# Altium schematic sheet Port.SchDoc
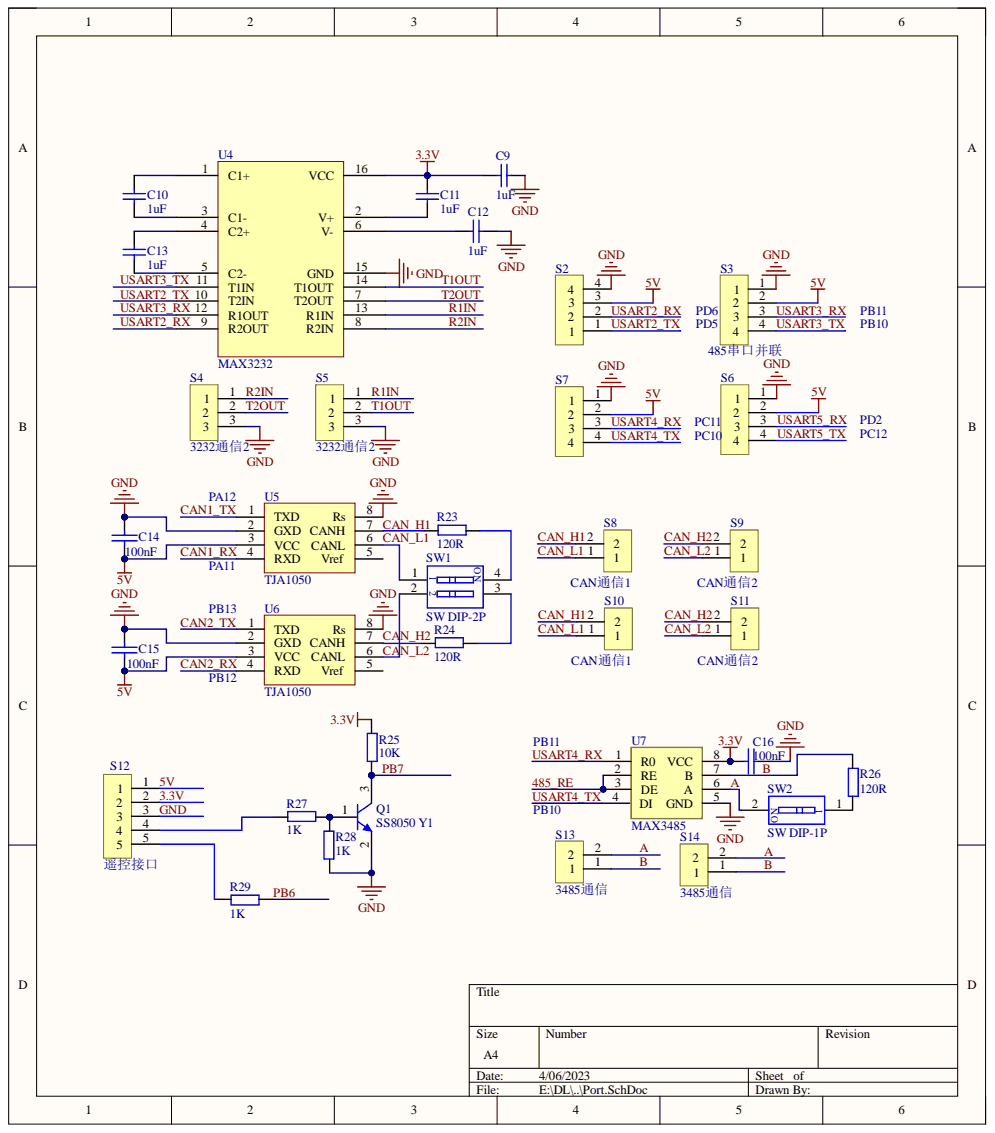
[diagram p0: Port.SchDoc]

## Parts
C9  1uF  [0402_C]
C10  1uF  [0402_C]
C11  1uF  [0402_C]
C12  1uF  [0402_C]
C13  1uF  [0402_C]
C14  100nF  [0402_C]
C15  100nF  [0402_C]
C16  100nF  [0402_C]
Q1  SS8050 Y1  [SOT-23]
R23  120R  [0402_R]
R24  120R  [0402_R]
R25  10K  [0402_R]
R26  120R  [0402_R]
R27  1K  [0402_R]
R28  1K  [0402_R]
R29  1K  [0402_R]
S2  (no value)  [卧式PH2.0mm-4P]
S3  485串口并联  [HDR2.54-LI-4P]
S4  3232通信2  [卧式PH2.0mm-3P]
S5  3232通信2  [卧式PH2.0mm-3P]
S6  (no value)  [HDR2.54-LI-4P]
S7  (no value)  [HDR2.54-LI-4P]
S8  CAN通信1  [卧式PH2.0mm-2P]
S9  CAN通信2  [卧式PH2.0mm-2P]
S10  CAN通信1  [卧式PH2.0mm-2P]
S11  CAN通信2  [卧式PH2.0mm-2P]
S12  遥控接口  [GH1.25-WS-5P]
S13  3485通信  [卧式PH2.0mm-2P]
S14  3485通信  [卧式PH2.0mm-2P]
SW1  SW DIP-2P  [SW DIP-1.27-2P]
SW2  SW DIP-1P  [SW DIP-1.27-1P]
U4  MAX3232  [SOP16_M]
U5  TJA1050  [SOP8_M]
U6  TJA1050  [SOP8_M]
U7  MAX3485  [SOP8_M]

## Nets
3.3V: C9.1 C11.2 C16.1 R25.2 S12.2 U4.16(VCC) U7.8(VCC)
5V: C14.2 C15.2 S2.3 S3.2 S6.2 S7.2 S12.1 U5.3(VCC) U6.3(VCC)
485_RE: U7.2(RE) U7.3(DE)
A: S13.2 S14.2 SW2.2 U7.6(A)
B: R26.2 S13.1 S14.1 U7.7(B)
CAN1_RX: U5.4(RXD)
CAN1_TX: U5.1(TXD)
CAN2_RX: U6.4(RXD)
CAN2_TX: U6.1(TXD)
CAN_H1: R23.2 S8.2 S10.2 U5.7(CANH)
CAN_H2: R24.2 S9.2 S11.2 U6.7(CANH)
CAN_L1: S8.1 S10.1 SW1.1 U5.6(CANL)
CAN_L2: S9.1 S11.1 SW1.2 U6.6(CANL)
GND: C9.2 C12.2 C14.1 C15.1 C16.2 Q1.2 R28.2 S2.4 S3.1 S4.3 S5.3 S6.1 S7.1 S12.3 U4.15(GND) U5.2(GXD) U5.8(Rs) U6.2(GXD) U6.8(Rs) U7.5(GND)
PB6: R29.2
PB7: Q1.3 R25.1
R1IN: S5.1 U4.13(R1IN)
R2IN: S4.1 U4.8(R2IN)
T1OUT: S5.2 U4.14(T1OUT)
T2OUT: S4.2 U4.7(T2OUT)
USART2_RX: S2.2 U4.9(R2OUT)
USART2_TX: S2.1 U4.10(T2IN)
USART3_RX: S3.3 U4.12(R1OUT)
USART3_TX: S3.4 U4.11(T1IN)
USART4_RX: S7.3 U7.1(R0)
USART4_TX: S7.4 U7.4(DI)
USART5_RX: S6.3
USART5_TX: S6.4
NetC10_1: C10.1 U4.3(C1-)
NetC10_2: C10.2 U4.1(C1+)
NetC11_1: C11.1 U4.2(V+)
NetC12_1: C12.1 U4.6(V-)
NetC13_1: C13.1 U4.5(C2-)
NetC13_2: C13.2 U4.4(C2+)
NetQ1_1: Q1.1 R27.1 R28.1
NetR23_1: R23.1 SW1.4
NetR24_1: R24.1 SW1.3
NetR26_1: R26.1 SW2.1
NetR27_2: R27.2 S12.4
NetR29_1: R29.1 S12.5

Not connected: U5 5(Vref); U6 5(Vref)

## Library data of the parts
C9-C13 = Cap: 无极性电容; Value: 100nF; Component Kind: Standard; LatestRevisionDate: 17-Jul-2002; LatestRevisionNote: Re-released for DXP Platform.; PackageReference: RAD-0.3; Published: 8-Jun-2000; Library Name: Fszhang.IntLib; Publisher: Altium Limited
C14-C16 = CAP-1: Component Kind: Standard; Library Name: RM9250.2.SCHLIB
Q1 = SOT-23 SS8050 Y1: 三极管; Component Kind: Standard; ComponentLink1Description: 供应商链接; ComponentLink1URL: http://www.szlcsc.com/product/details_2507.html; Library Name: JLC.IntLib; Package: SOT-23; Supplier: LC; SuppliersPartNumber: C2150
R23-R29 = R: Component Kind: Standard; Library Name: RM9250.2.SCHLIB
S2 S3 S6 S7 = S-4: Component Kind: Standard; Library Name: ZBC123Schlib1.SchLib
S4 S5 = S-3: Component Kind: Standard; Library Name: ZBC123Schlib1.SchLib
S8-S11 S13 S14 = S-2: Component Kind: Standard; Library Name: ZBC123Schlib1.SchLib
S12 = S-5: Component Kind: Standard; Library Name: ZBC123Schlib1.SchLib
SW1 = SW DIP-2P: DIP Switch, 2 Position, SPST; Code_IPC: SOIC127P600-8; Code_JEDEC: MS-012-AA; Component Kind: Standard; LatestRevisionDate: 29-May-2009; LatestRevisionNote: IPC-7351 Footprint Added.; Library Name: Master Li.SchLib; PackageDescription: 8-Pin Small Outline Integrated Circuit 1.27 mm Pitch; PackageReference: SO8; PackageVersion: Dec-2002; Published: 24-Mar-1999; Publisher: Altium Limited
SW2 = SW DIP-1P: DIP Switch, 1 Position, SPST; Code_IPC: SOIC127P600-8; Code_JEDEC: MS-012-AA; Component Kind: Standard; LatestRevisionDate: 29-May-2009; LatestRevisionNote: IPC-7351 Footprint Added.; Library Name: Master Li.SchLib; PackageDescription: 8-Pin Small Outline Integrated Circuit 1.27 mm Pitch; PackageReference: SO8; PackageVersion: Dec-2002; Published: 24-Mar-1999; Publisher: Altium Limited
U4 = MAX232: Component Kind: Standard; Library Name: ZBC124Schlib1.SchLib
U5 U6 = 24C02: Component Kind: Standard; Library Name: RM9250.2.SCHLIB
U7 = MAX485: Component Kind: Standard; Library Name: ZBC124Schlib1.SchLib
